# Altium schematic sheet Heater.SchDoc(Heater)
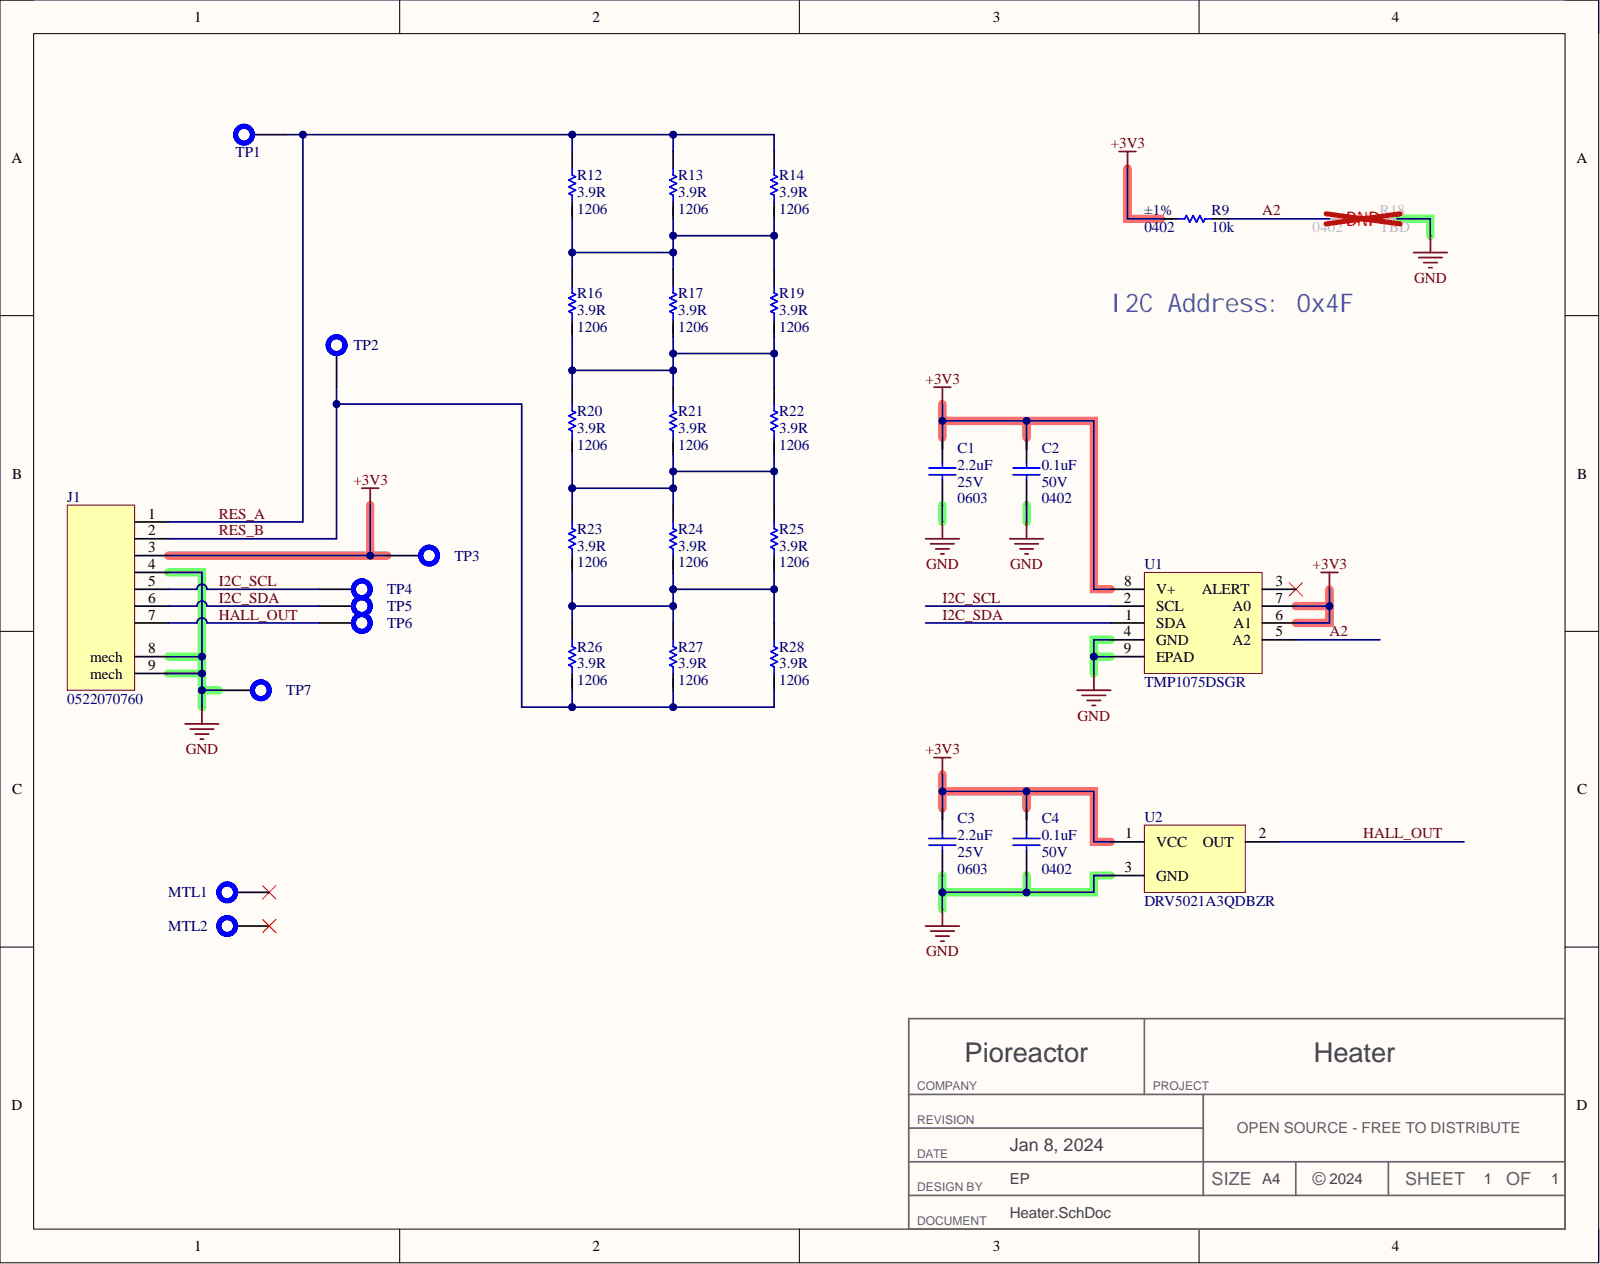
[diagram p0: Heater.SchDoc]

## Parts
C1  value 2.2uF  Package: 0603  Voltage: 25V  [C_0603_1.0mm]  (hidden comment: CAP_NON_POLAR)
C2  value 0.1uF  Package: 0402  Voltage: 50V  [C_0402_0.7mm]  (hidden comment: CAP_NON_POLAR)
C3  value 2.2uF  Package: 0603  Voltage: 25V  [C_0603_1.0mm]  (hidden comment: CAP_NON_POLAR)
C4  value 0.1uF  Package: 0402  Voltage: 50V  [C_0402_0.7mm]  (hidden comment: CAP_NON_POLAR)
J1  0522070760  [CONN_0522070760_2.7mm]
MTL1  9774030151R  [MTL_9774030151R]  (value not drawn)
MTL2  9774030151R  [MTL_9774030151R]  (value not drawn)
R9  value 10k  Package: 0402  Tolerance: ±1%  [R_0402_0.35mm]  (hidden comment: RESISTOR)
R12  value 3.9R  Package: 1206  [R_1206_0.55mm]  (hidden comment: RESISTOR)
R13  value 3.9R  Package: 1206  [R_1206_0.55mm]  (hidden comment: RESISTOR)
R14  value 3.9R  Package: 1206  [R_1206_0.55mm]  (hidden comment: RESISTOR)
R16  value 3.9R  Package: 1206  [R_1206_0.55mm]  (hidden comment: RESISTOR)
R17  value 3.9R  Package: 1206  [R_1206_0.55mm]  (hidden comment: RESISTOR)
R18  value TBD  Package: 0402  [R_0402_0.35mm]  (hidden comment: RESISTOR)
R19  value 3.9R  Package: 1206  [R_1206_0.55mm]  (hidden comment: RESISTOR)
R20  value 3.9R  Package: 1206  [R_1206_0.55mm]  (hidden comment: RESISTOR)
R21  value 3.9R  Package: 1206  [R_1206_0.55mm]  (hidden comment: RESISTOR)
R22  value 3.9R  Package: 1206  [R_1206_0.55mm]  (hidden comment: RESISTOR)
R23  value 3.9R  Package: 1206  [R_1206_0.55mm]  (hidden comment: RESISTOR)
R24  value 3.9R  Package: 1206  [R_1206_0.55mm]  (hidden comment: RESISTOR)
R25  value 3.9R  Package: 1206  [R_1206_0.55mm]  (hidden comment: RESISTOR)
R26  value 3.9R  Package: 1206  [R_1206_0.55mm]  (hidden comment: RESISTOR)
R27  value 3.9R  Package: 1206  [R_1206_0.55mm]  (hidden comment: RESISTOR)
R28  value 3.9R  Package: 1206  [R_1206_0.55mm]  (hidden comment: RESISTOR)
TP1  TP  [TP_2mm]  (no BOM)
TP2  TP  [TP_2mm]  (no BOM)
TP3  TP  [TP_2mm]  (no BOM)
TP4  TP  [TP_2mm]  (no BOM)
TP5  TP  [TP_2mm]  (no BOM)
TP6  TP  [TP_2mm]  (no BOM)
TP7  TP  [TP_2mm]  (no BOM)
U1  TMP1075DSGR  [IC_TMP1075DSGR_0.8mm]
U2  DRV5021A3QDBZR  [IC_DRV5021A3QDBZR_1.2mm]

## Nets
+3V3: C1.1 C2.1 C3.1 C4.1 J1.3 R9.1 TP3.1 U1.6(A1) U1.7(A0) U1.8(V+) U2.1
GND: C1.2 C2.2 C3.2 C4.2 J1.4 J1.8(mech) J1.9(mech) R18.2 TP7.1 U1.4(GND) U1.9(EPAD) U2.3
A2: R9.2 R18.1 U1.5(A2)
HALL_OUT: J1.7 TP6.1 U2.2
I2C_SCL: J1.5 TP4.1 U1.2(SCL)
I2C_SDA: J1.6 TP5.1 U1.1(SDA)
RES_A: J1.1 R12.2 R13.2 R14.2 TP1.1
RES_B: J1.2 R26.1 R27.1 R28.1 TP2.1
NetR12_1: R12.1 R13.1 R14.1 R16.2 R17.2 R19.2
NetR16_1: R16.1 R17.1 R19.1 R20.2 R21.2 R22.2
NetR20_1: R20.1 R21.1 R22.1 R23.2 R24.2 R25.2
NetR23_1: R23.1 R24.1 R25.1 R26.2 R27.2 R28.2

Not connected: MTL1 1; MTL2 1; U1 3(ALERT)

## Library data of the parts
C1 C3 = GRM188R61E225MA12D: CAP CER 2.2UF 25V X5R 0603; Manufacturer 1: Murata Electronics; Database Table Name: Capacitors$; Tolerance: ±20%; Used: Yes; Component Kind: Standard; ComponentLink1Description: Vendor URL; ComponentLink1URL: https://www.digikey.com/product-detail/en/murata-electronics/GRM188R61E225MA12D/490-12738-1-ND/5797707; Library Name: altium_database.DbLib; Manufacturer Part Number 1: GRM188R61E225MA12D; Supplier 1: Digi-Key; Supplier Part Number 1: 490-12738-1-ND; Temp Coefficient: X5R
C2 C4 = GRM155R71H104KE14J: CAP CER 0.1UF 50V X7R 0402; Manufacturer 1: Murata Electronics; Database Table Name: Capacitors$; Tolerance: ±10%; Used: Yes; Component Kind: Standard; ComponentLink1Description: Vendor URL; ComponentLink1URL: https://www.digikey.com/product-detail/en/murata-electronics/GRM155R71H104KE14J/490-13342-1-ND/5973352; Library Name: altium_database.DbLib; Manufacturer Part Number 1: GRM155R71H104KE14J; Supplier 1: Digi-Key; Supplier Part Number 1: 490-13342-1-ND; Temp Coefficient: X7R
J1 = 0522070760: CONN FFC FPC TOP 7POS 1.00MM R/A; Manufacturer 1: Molex; Database Table Name: Connectors$; PcbChecked: EP; Used: Yes; Component Kind: Standard; ComponentLink1Description: Vendor URL; ComponentLink1URL: https://www.digikey.ca/en/products/detail/0522070760/WM3818CT-ND/2421682?itemSeq=379269879; Library Name: altium_database.DbLib; Manufacturer Part Number 1: 0522070760; Pins: 9; Supplier 1: Digi-key; Supplier Part Number 1: WM3818TR-ND; Value: 0522070760; SchChecked: EP
MTL1 MTL2 = 9774030151R: RND STANDOFF M2.5X0.45 STEEL 3MM; Manufacturer 1: Würth Elektronik; Database Table Name: Misc Electrical$; PcbChecked: EP; Used: Yes; Component Kind: Standard; ComponentLink1Description: Vendor URL; ComponentLink1URL: https://www.digikey.com/en/products/detail/w%C3%BCrth-elektronik/9774030151R/5320626; Library Name: altium_database.DbLib; Manufacturer Part Number 1: 9774030151R; Supplier 1: Digi-Key; Supplier Part Number 1: 732-7083-1-ND; Type: STANDOFF; Value: 9774030151R; SchChecked: EP
R9 = RC0402FR-0710KL: RES SMD 10K OHM 1% 1/16W 0402; Manufacturer 1: Yageo; Database Table Name: Resistor$; Used: Yes; Component Kind: Standard; ComponentLink1Description: Vendor URL; ComponentLink1URL: https://www.digikey.com/product-detail/en/yageo/RC0402FR-0710KL/311-10-0KLRCT-ND/729470; Library Name: altium_database.DbLib; Manufacturer Part Number 1: RC0402FR-0710KL; Power: 1/16W; Supplier 1: Digi-Key; Supplier Part Number 1: 311-10.0KLRCT-ND
R12-R14 R16 R17 R19-R28 = ESR18EZPJ3R9: RES SMD 3.9 OHM 5% 1/2W 1206; Manufacturer 1: Rohm Semiconductor; Database Table Name: Resistor$; Power: 0.5W; Used: Yes; Component Kind: Standard; ComponentLink1Description: Vendor URL; ComponentLink1URL: https://www.digikey.com/en/products/detail/rohm-semiconductor/ESR18EZPJ3R9/1983579; Library Name: altium_database.DbLib; Manufacturer Part Number 1: ESR18EZPJ3R9; Supplier 1: Digi-Key; Supplier Part Number 1: RHM3.9ITR-ND; Tolerance: ±5%
R18 = res_generic_0402: RES 0402 TBD; Database Table Name: Resistor$; Component Kind: Standard; Library Name: altium_database.DbLib; Manufacturer Part Number 1: res_generic_0402
TP1-TP7 = TP_2mm: SMT Testpoint 2mm; Database Table Name: No_BOM$; Value: TP_2mm; Library Name: altium_database.DbLib; Type: TESTPOINT
U1 = TMP1075DSGR: IC TEMP SENSOR; Manufacturer 1: Texas Instruments; Database Table Name: IC$; PcbChecked: EP; Used: Yes; Component Kind: Standard; ComponentLink1Description: Vendor URL; ComponentLink1URL: https://www.digikey.com/en/products/detail/texas-instruments/TMP1075DSGR/10715322?s=N4IgTCBcDaIC4FsAOBGADAdgKwBMDOA5gE4gC6AvkA; Library Name: altium_database.DbLib; Manufacturer Part Number 1: TMP1075DSGR; Package: 8-WSON; Supplier 1: Digi-key; Supplier Part Number 1: 296-TMP1075DSGRTR-ND; Type: SENSOR; Value: TMP1075DSGR; SchChecked: EP
U2 = DRV5021A3QDBZR: HALL EFFECT SENSOR; Manufacturer 1: Texas Instruments; Database Table Name: IC$; PcbChecked: EP; Used: Yes; Component Kind: Standard; ComponentLink1Description: Vendor URL; ComponentLink1URL: https://www.digikey.com/en/products/detail/texas-instruments/DRV5021A3QDBZR/10434814; Library Name: altium_database.DbLib; Manufacturer Part Number 1: DRV5021A3QDBZR; Package: SOT23-3; Supplier 1: Digi-key; Supplier Part Number 1: 296-DRV5021A3QDBZRCT-ND; Type: SENSOR; Value: DRV5021A3QDBZR; SchChecked: EP
